# Altium schematic sheet MCU and POWER.SchDoc("MCU and POWER")
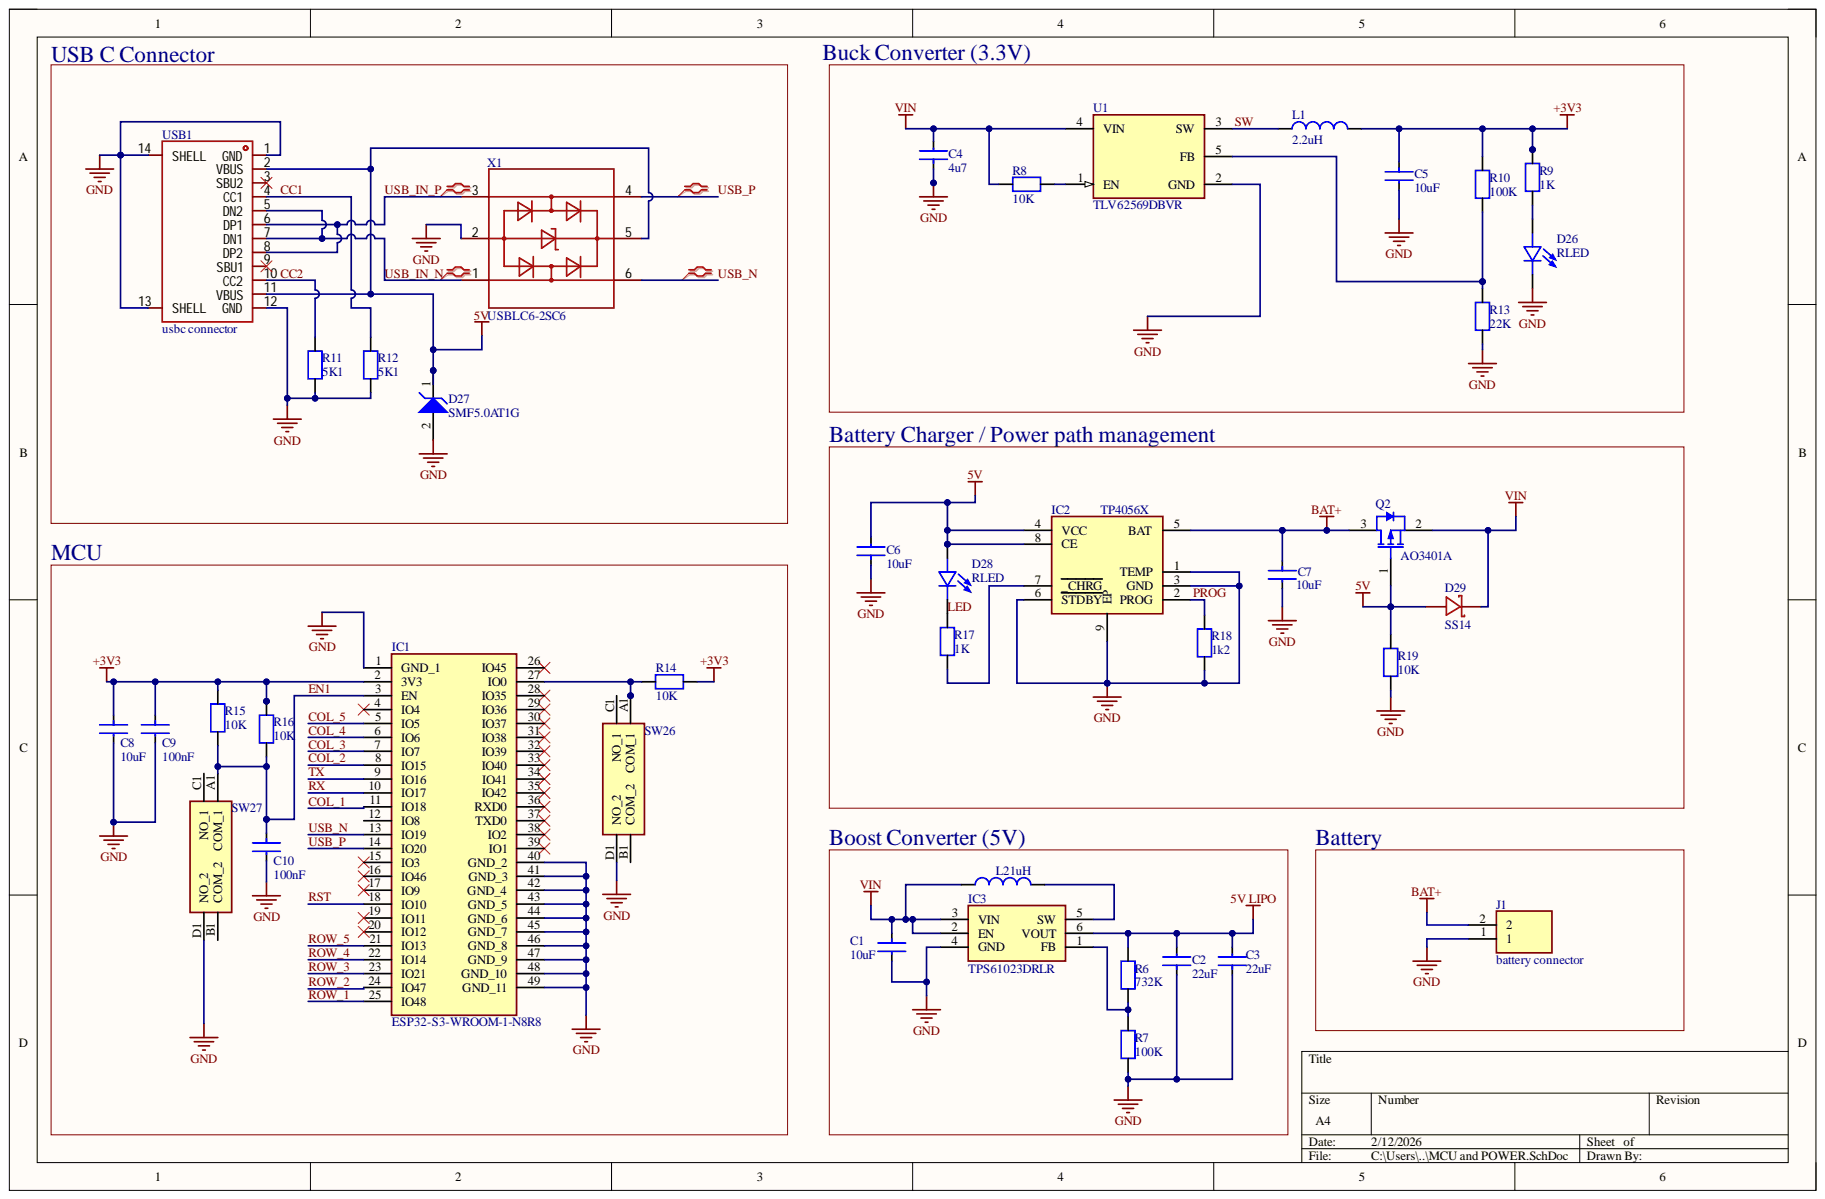
[diagram p0: MCU and POWER.SchDoc]

## Parts
C1  10uF  [0402 capacitor]
C2  22uF  [0402 capacitor]
C3  22uF  [0402 capacitor]
C4  4u7  [0402 capacitor]
C5  10uF  [0402 capacitor]
C6  10uF  [0603 CAPACITOR]
C7  10uF  [0603 CAPACITOR]
C8  10uF  [0603 CAPACITOR]
C9  100nF  [0402 capacitor]
C10  100nF  [0402 capacitor]
D26  RLED  [LED_KT-0603R]
D27  SMF5.0AT1G  [ONSC-SOD-123FL-2-498_V]
D28  RLED  [LED_KT-0603R]
D29  SS14  [SMA(DO-214AC)(schottky)]
IC1  ESP32-S3-WROOM-1-N8R8  [ESP32-S3-WROOM-1-N8R8]
IC2  TP4056X  [SOIC127P600X175-9N]
IC3  TPS61023DRLR  [SOTFL50P160X60-6N]
J1  battery connector  [2pin wire to board]
L1  2.2uH  [0603 inductor]
L2  1uH  [0802 inductor]  (value not drawn)
Q2  AO3401A  [AO-SOT-23-3L_V]
R6  732K  [0402 resistor]
R7  100K  [0402 resistor]
R8  10K  [0603 resistor]
R9  1K  [0402 resistor]
R10  100K  [0603 resistor]
R11  5K1  [0603 resistor]
R12  5K1  [0603 resistor]
R13  22K  [0603 resistor]
R14  10K  [0603 resistor]
R15  10K  [0603 resistor]
R16  10K  [0603 resistor]
R17  1K  [0402 resistor]
R18  1k2  [0603 resistor]
R19  10K  [0603 resistor]
SW26  push button  [push button]  (value not drawn)
SW27  push button  [push button]  (value not drawn)
U1  TLV62569DBVR  [FP-DBV0005A-MFG]
USB1  usbc connector  [USBC connector]
X1  USBLC6-2SC6  [STM-SOT23-6L_V]

## Nets
5V: C6.2 D27.1 D28.A D29.2 IC2.4(VCC) IC2.8(CE) Q2.1 R19.2 USB1.2(VBUS) USB1.11(VBUS) X1.5
5V LIPO: C2.2 C3.2 IC3.6(VOUT) R6.2
+3V3: C5.1 C8.1 C9.1 IC1.2(3V3) L1.2 R9.2 R10.2 R14.2 R15.2 R16.2
BAT+: C7.1 IC2.5(BAT) J1.2 Q2.3
GND: C1.2 C2.1 C3.1 C4.1 C5.2 C6.1 C7.2 C8.2 C9.2 C10.2 D26.C D27.2 IC1.1(GND_1) IC1.40(GND_2) IC1.41(GND_3) IC1.42(GND_4) IC1.43(GND_5) IC1.44(GND_6) IC1.45(GND_7) IC1.46(GND_8) IC1.47(GND_9) IC1.48(GND_10) IC1.49(GND_11) IC2.1(TEMP) IC2.3(GND) IC2.6(STDBY) IC2.9(EP) IC3.4(GND) J1.1 R7.1 R11.1 R12.1 R13.1 R18.1 R19.1 SW26.D1(NO_2) SW27.D1(NO_2) U1.2(GND) USB1.1(GND) USB1.12(GND) USB1.13(SHELL) USB1.14(SHELL) X1.2
VIN: C1.1 C4.2 D29.1 IC3.2(EN) IC3.3(VIN) L2.1 Q2.2 R8.2 U1.4(VIN)
CC1: R12.2 USB1.4(CC1)
CC2: R11.2 USB1.10(CC2)
COL_1: IC1.11(IO18)
COL_2: IC1.8(IO15)
COL_3: IC1.7(IO7)
COL_4: IC1.6(IO6)
COL_5: IC1.5(IO5)
EN1: C10.1 IC1.3(EN) R15.1 R16.1 SW27.A1(COM_1)
LED: D28.C R17.2
PROG: IC2.2(PROG) R18.2
ROW_1: IC1.25(IO48)
ROW_2: IC1.24(IO47)
ROW_3: IC1.23(IO21)
ROW_4: IC1.22(IO14)
ROW_5: IC1.21(IO13)
RST: IC1.18(IO10)
RX: IC1.10(IO17)
SW: L1.1 U1.3(SW)
TX: IC1.9(IO16)
USB_IN_N: USB1.5(DN2) USB1.7(DN1) X1.1
USB_IN_P: USB1.6(DP1) USB1.8(DP2) X1.3
USB_N: IC1.13(IO19) X1.6
USB_P: IC1.14(IO20) X1.4
NetD26_A: D26.A R9.1
NetIC1_27: IC1.27(IO0) R14.1 SW26.A1(COM_1)
NetIC2_7: IC2.7(_CHRG) R17.1
NetIC3_1: IC3.1(FB) R6.1 R7.2
NetIC3_5: IC3.5(SW) L2.2
NetR8_1: R8.1 U1.1(EN)
NetR10_1: R10.1 R13.2 U1.5(FB)

Not connected: IC1 4(IO4) 12(IO8) 15(IO3) 16(IO46) 17(IO9) 19(IO11) 20(IO12) 26(IO45) 28(IO35) 29(IO36) 30(IO37) 31(IO38) 32(IO39) 33(IO40) 34(IO41) 35(IO42) 36(RXD0) 37(TXD0) 38(IO2) 39(IO1); SW26 B1(COM_2) C1(NO_1); SW27 B1(COM_2) C1(NO_1); USB1 3(SBU2) 9(SBU1)

## Library data of the parts
C1-C5 C9 C10 = 0402 capacitor: 0402 smd capacitor; Component Kind: Standard; Library Name: schematiclibrary.SchLib
C6-C8 = 0603 capacitor: Component Kind: Standard; Library Name: schematiclibrary.SchLib
D26 D28 = KT-0603R: Red 0603 Light Emitting Diodes; MF: Hubei KENTO Elec; Check_prices: https://www.snapeda.com/parts/KT-0603R/Hubei+KENTO+Elec/view-part/?ref=eda; Component Kind: Standard; Library Name: KT-0603R.IntLib; MP: KT-0603R; Package: Package; SnapEDA_Link: https://www.snapeda.com/parts/KT-0603R/Hubei+KENTO+Elec/view-part/?ref=snap
D27 = CMP-1061-00612-1: 200 W Transient Voltage Suppressor, 2-Pin SOD-123FL, Pb-Free, Tape and Reel; Manufacturer: On Semiconductor; Direction: Unidirectional; IR Max (uA): 400; V(BR) Typ (V): 6.7; Component Kind: Standard; ComponentLink1Description: Manufacturer URL; ComponentLink1URL: http://www.onsemi.com/; ComponentLink2Description: Datasheet; ComponentLink2URL: http://www.onsemi.com/pub_link/Collateral/SMF5.0AT1-D.PDF; ComponentLink3Description: Package Specification; ComponentLink3URL: http://www.onsemi.com/pub_link/Collateral/498-01.PDF; DatasheetVersion: Rev. 7; Library Name: Altium Content Vault; Mounting Technology: Surface Mount; PackageDescription: 2-Pin SOD, Body 1.65 x 2.7 mm; PackageReference: SOD-123FL-2-498; PackageVersion: Rev. D, 05/2013; Packing: Tape and Reel; PartNumber: SMF5.0AT1G; RoHS: Pb-Free
D29 = ss14: 40V Independent Type 1A 550mV@1A SMA(DO-214AC) Schottky Diodes ROHS; Component Kind: Standard; Library Name: schematiclibrary.SchLib
IC2 = TP4056X: Integrated Circuit; Manufacturer_Name: Nanjing Extension Microelectronics; Component Kind: Standard; Datasheet Link: https://datasheet.lcsc.com/szlcsc/Nanjing-Extension-Microelectronics-TP4056X_C191323.pdf; Height: 1.75mm; Library Name: SamacSys.SchLib; Manufacturer_Part_Number: TP4056X
IC3 = TPS61023DRLR: Integrated Circuit; Manufacturer_Name: Texas Instruments; Arrow Part Number: TPS61023DRLR; Arrow Price/Stock: https://www.arrow.com/en/products/tps61023drlr/texas-instruments?utm_currency=USD&region=nac; Component Kind: Standard; Datasheet Link: http://www.ti.com/lit/ds/symlink/tps61023.pdf; Height: 0.6mm; Library Name: SamacSys.SchLib; Manufacturer_Part_Number: TPS61023DRLR; Mouser Part Number: 595-TPS61023DRLR; Mouser Price/Stock: https://www.mouser.co.uk/ProductDetail/Texas-Instruments/TPS61023DRLR?qs=BJlw7L4Cy7%2FfEnwYuWeGOg%3D%3D
J1 = 2pin wire to board: Component Kind: Standard; Library Name: schematiclibrary.SchLib
L1 = 0603 inductor: Component Kind: Standard; Library Name: schematiclibrary.SchLib
L2 = 0805 inductor: Component Kind: Standard; Library Name: schematiclibrary.SchLib
Q2 = CMP-2000-05778-1: P-Channel MOSFET, 30 V, 4 A, -55 to 150 degC, 3-Pin SOT-23-3L, RoHS, Tape and Reel; Manufacturer: Alpha and Omega; Channel Mode: Enhancement; Continuous Drain Current: 4A; Drain Efficiency: NotRequired%; Drain-Source On-Res: 0.044ohm; Drain-Source On-Volt: 30V; Frequency (Max): NotRequiredMHz; Gate-Source Voltage (Max): ?12V; Noise Figure: NotRequireddB; Number of  Elements: 1; Output Power (Max): NotRequiredW; Polarity: P; Power Dissipation: 1.4W; Rad Hardened: No; Type: PowerMOSFET; Component Kind: Standard; Datasheet URL: http://www.aosmd.com/res/data_sheets/AO3401A.pdf; Datasheet Version: Rev. 3, 03/2011; Drain Current (Max): 4A; Library Name: Altium Content Vault; Manufacturer URL: http://www.aosmd.com/; Mounting: SurfaceMount; Mounting Technology: SurfaceMount; Operating Temp Range: -55Cto150C; Operating Temperature Classification: Military; Package Description: 3-Pin Small Outline Transistor, Body 2.95 x 1.6 mm, Pitch 0.95 mm; Package Reference: SOT-23-3L; Package Type: SOT-23; Package URL: http://www.aosmd.com/res/packaging_information/SOT-23-3L.pdf; Pin Count: 3; Power Gain: NotRequireddB
R6 R7 R9 R17 = 0402 resistor: Component Kind: Standard; Library Name: schematiclibrary.SchLib
R8 R10-R16 R18 R19 = 0603 resistor: Component Kind: Standard; Library Name: schematiclibrary.SchLib
U1 = CMP-04918-000387-1: IC REG BUCK ADJ 2A SOT23-5; Max Input Voltage: 5.5V; Min Input Voltage: 2.5V; Min Output Voltage: 600mV; Output Configuration: Positive; Output Type: Adjustable; Switching Frequency: 1.5MHz; Synchronous Rectifier: Yes; Case/Package: SOT-23-5; Component Kind: Standard; Library Name: Altium Content Vault; Max Operating Temperature: 125°C; Max Output Voltage: 5.5V; Min Operating Temperature: -40°C; Mount: Surface Mount; Output Current: 2A; Packaging: Cut Tape; RoHS Compliant: Yes
IC1 = ESP32-S3-WROOM-1-N8R8: Component Kind: Standard; Library Name: schematiclibrary.SchLib
SW26 SW27 = push button: Component Kind: Standard; Library Name: schematiclibrary.SchLib
USB1 = usbc connector: Component Kind: Standard; Library Name: schematiclibrary.SchLib
X1 = USBLC6-2SC6: Component Kind: Standard; Library Name: schematiclibrary.SchLib
